# Altium schematic sheet [13] - HDMI_FILTERS.SchDoc
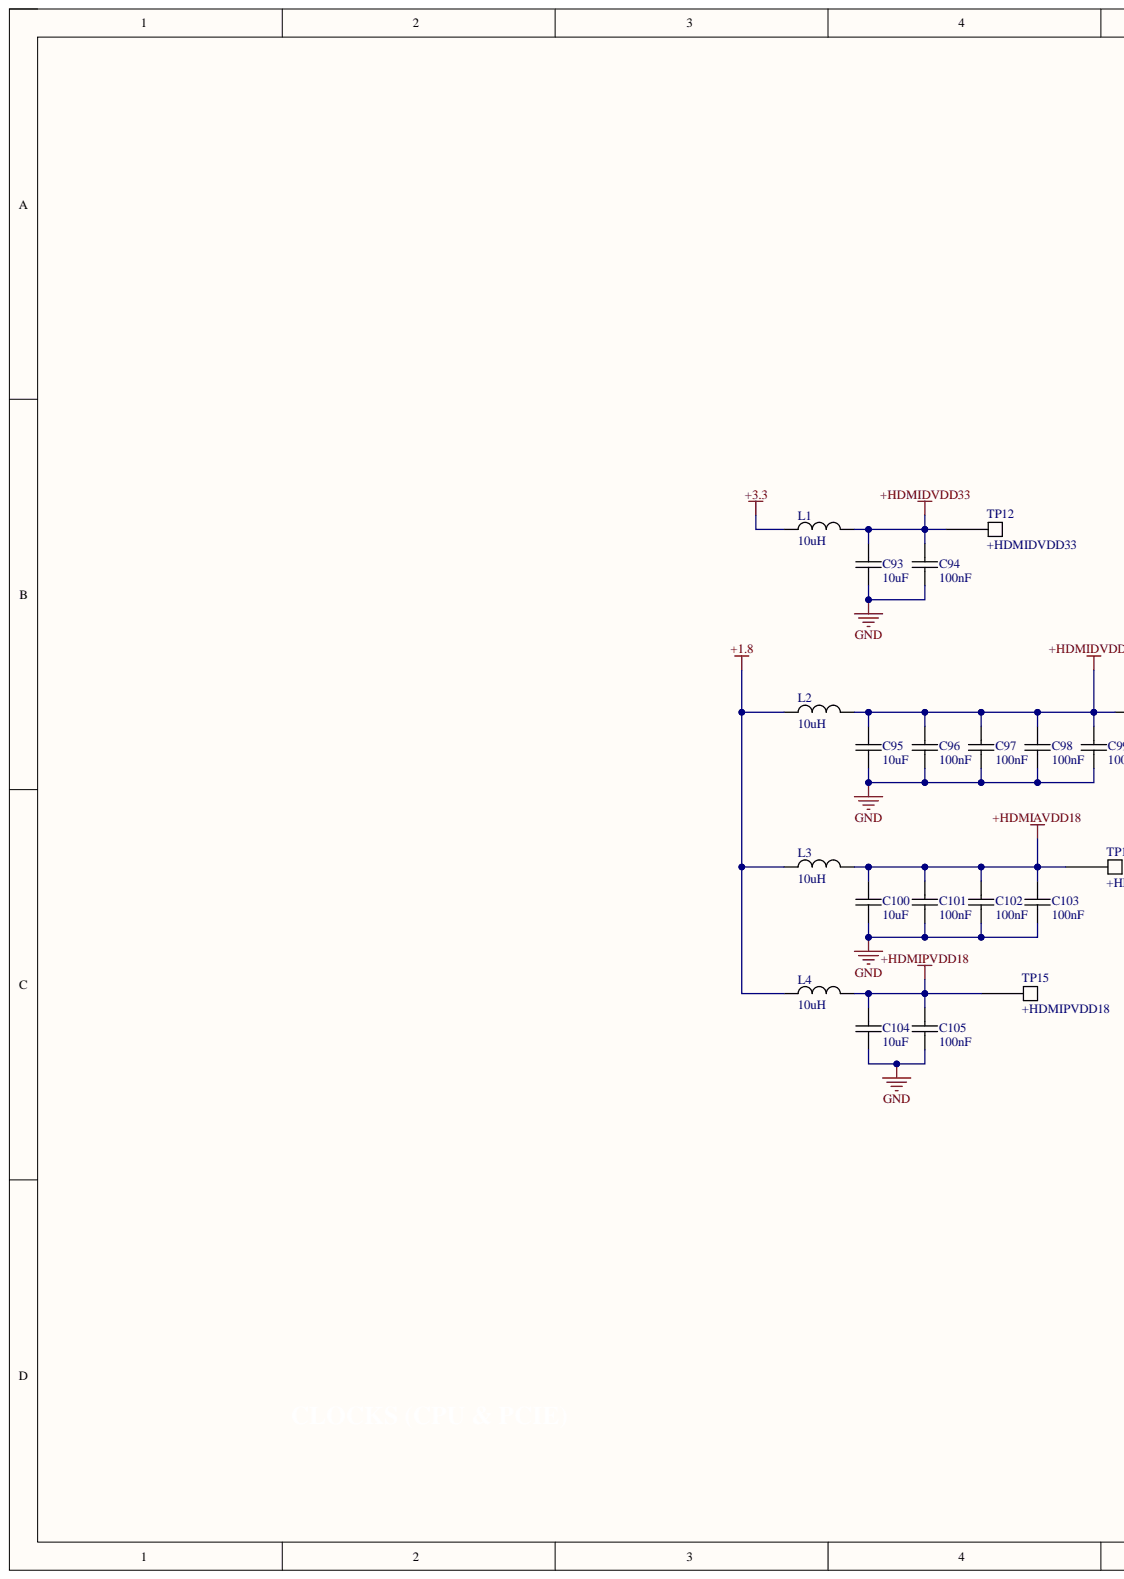
[diagram p0: [13] - HDMI_FILTERS.SchDoc, left]
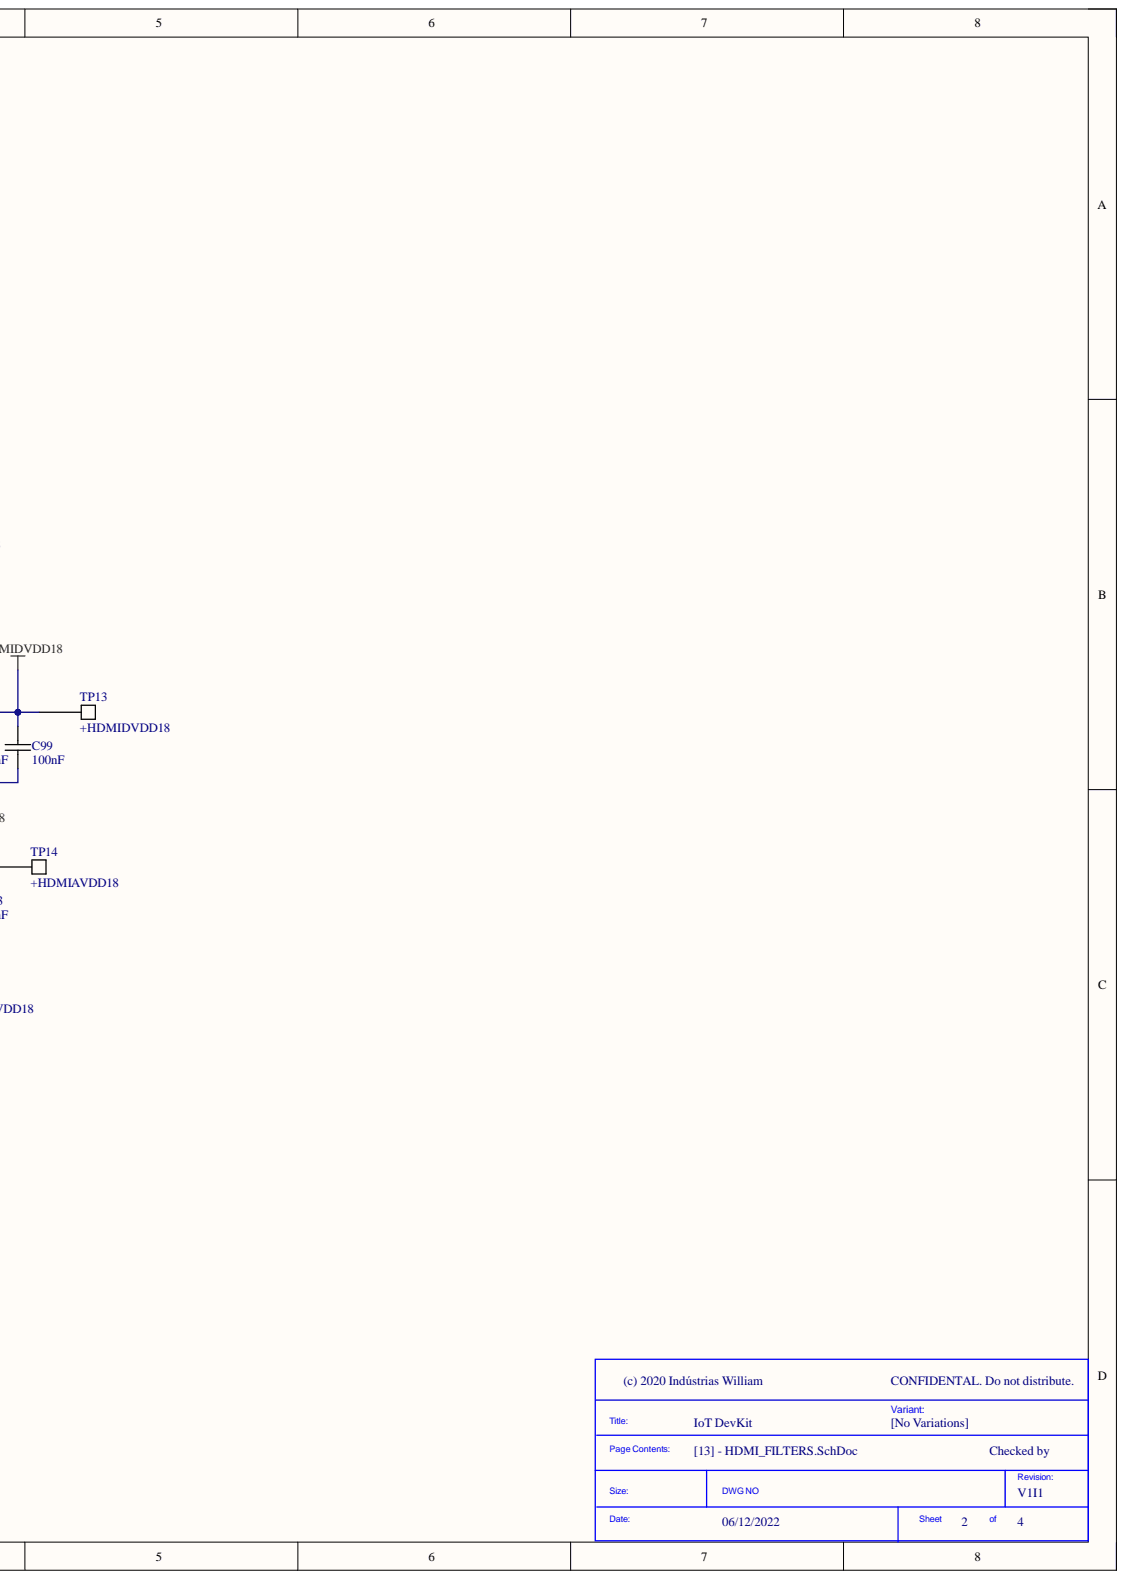
[diagram p1: [13] - HDMI_FILTERS.SchDoc, right]

## Parts
C93  10uF  [0603C-GENERIC]
C94  100nF  [0603C-GENERIC]
C95  10uF  [0603C-GENERIC]
C96  100nF  [0603C-GENERIC]
C97  100nF  [0603C-GENERIC]
C98  100nF  [0603C-GENERIC]
C99  100nF  [0603C-GENERIC]
C100  10uF  [0603C-GENERIC]
C101  100nF  [0603C-GENERIC]
C102  100nF  [0603C-GENERIC]
C103  100nF  [0603C-GENERIC]
C104  10uF  [0603C-GENERIC]
C105  100nF  [0603C-GENERIC]
L1  10uH  [0603C-TBD]
L2  10uH  [0603FB-GENERIC]
L3  10uH  [0603C-GENERIC]
L4  10uH  [0603C-GENERIC]
TP12  +HDMIDVDD33  [TestPoint]
TP13  +HDMIDVDD18  [TestPoint]
TP14  +HDMIAVDD18  [TestPoint]
TP15  +HDMIPVDD18  [TestPoint]

## Nets
+1.8: L2.1 L3.1 L4.1
+3.3: L1.1
+HDMIAVDD18: C100.1 C101.1 C102.1 C103.1 L3.2 TP14.1
+HDMIDVDD18: C95.1 C96.1 C97.1 C98.1 C99.1 L2.2 TP13.1
+HDMIDVDD33: C93.1 C94.1 L1.2 TP12.1
+HDMIPVDD18: C104.1 C105.1 L4.2 TP15.1
GND: C93.2 C94.2 C95.2 C96.2 C97.2 C98.2 C99.2 C100.2 C101.2 C102.2 C103.2 C104.2 C105.2

## Library data of the parts
C93 C95 C100 C104 = 0603C-10UF-10V-X5R-TDK: CAPACITOR 0603 CERAMIC; Manufacturer: TDK Corporation; Manufacturer Part Number: C1608X5R0J106M; Reel: C31; Component Kind: Standard; Library Name: vh_altium_library.intLib; Supplier 1: Digi-Key; Supplier Part Number 1: 445-4112-2-ND
C94 C96-C99 C101-C103 C105 = 0603C-100NF-25V-X7R-TDK: CAPACITOR 0603 CERAMIC; Manufacturer: TDK Corporation; Manufacturer Part Number: C1608X7R1E104K; Reel: C12; Component Kind: Standard; Library Name: vh_altium_library.intLib; Supplier 1: Digi-Key; Supplier Part Number 1: 445-1316-2-ND
L1-L4 = INDUCTOR: Component Kind: Standard; Description: INDUCTOR; Library Name: vh_altium_library.intLib
TP12-TP15 = TestPoint: TESTE POINT; Component Kind: Standard; Library Name: Componentes Deived Projetos.IntLib
